# Altium schematic sheet ARK_Flow_Microcontroller.SchDoc(ARK_Flow_Microcontroller)
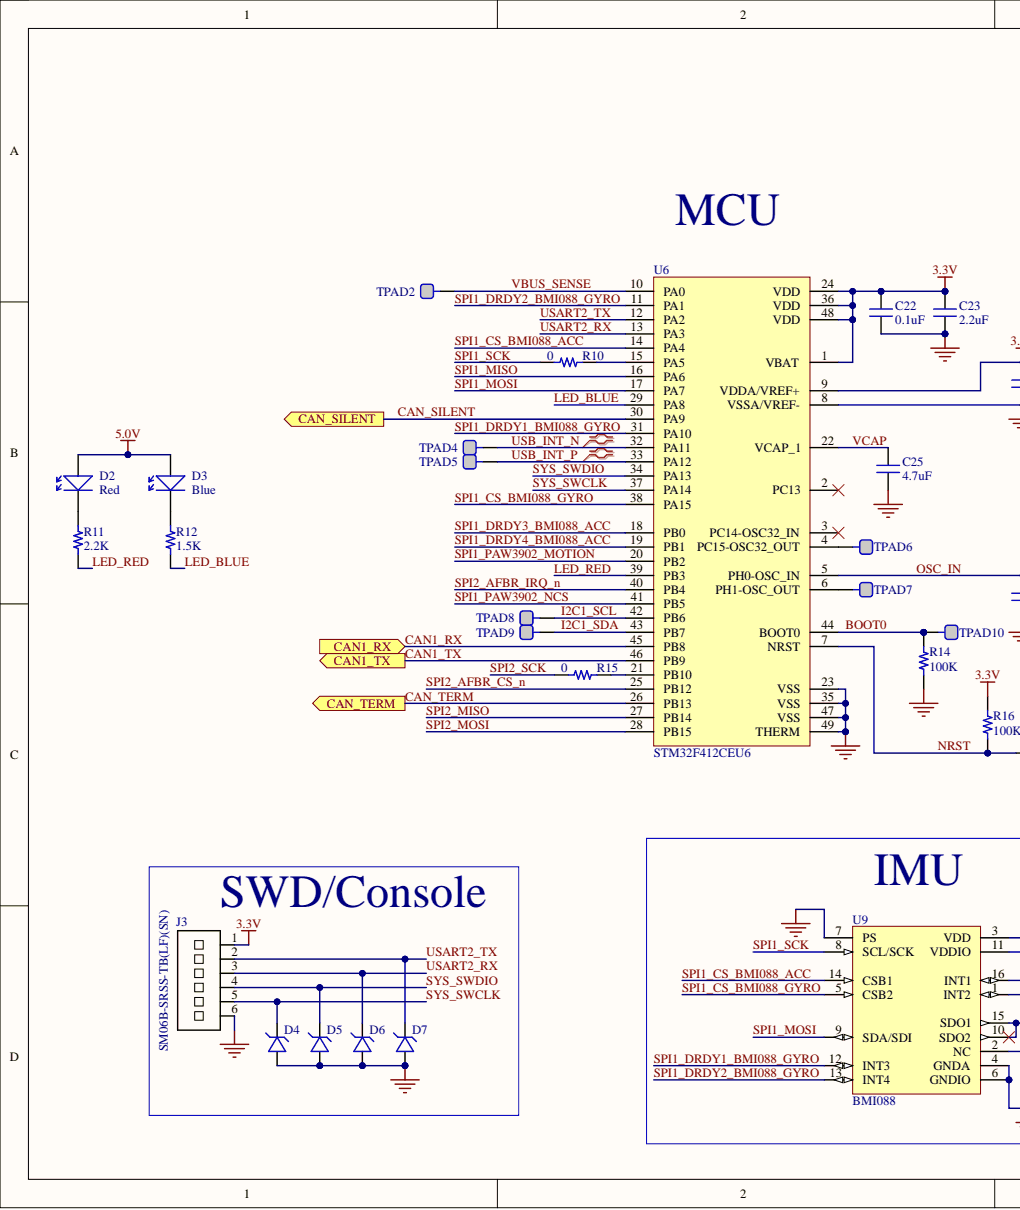
[diagram p0: ARK_Flow_Microcontroller.SchDoc, left]
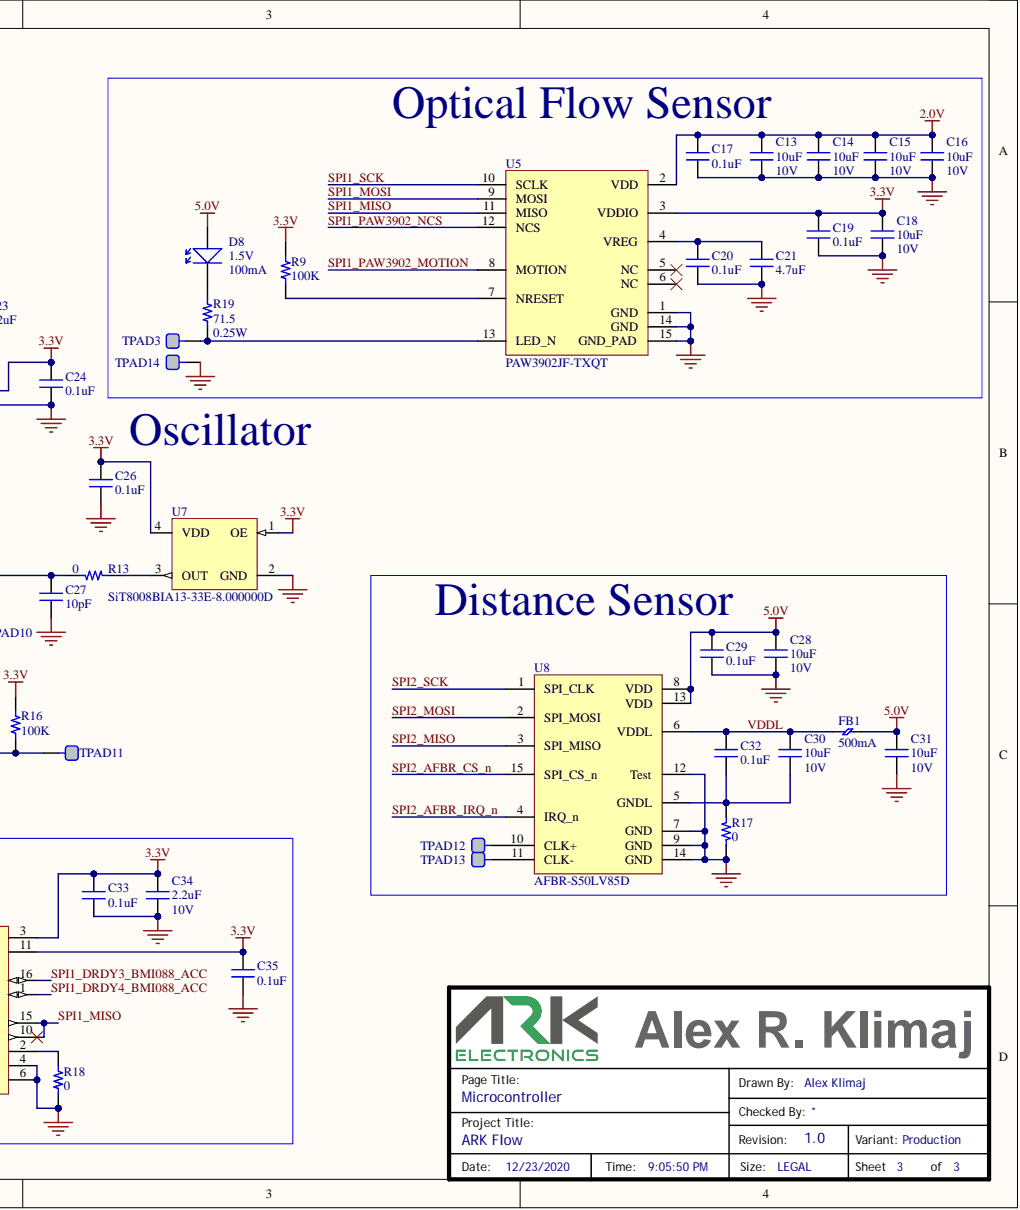
[diagram p1: ARK_Flow_Microcontroller.SchDoc, right]

## Parts
C13  10uF  Voltage_Rating: 10V  [CAP 0402/1005]
C14  10uF  Voltage_Rating: 10V  [CAP 0402/1005]
C15  10uF  Voltage_Rating: 10V  [CAP 0402/1005]
C16  10uF  Voltage_Rating: 10V  [CAP 0402/1005]
C17  value 0.1uF  [CAP 0402/1005]
C18  10uF  Voltage_Rating: 10V  [CAP 0402/1005]
C19  value 0.1uF  [CAP 0402/1005]
C20  value 0.1uF  [CAP 0402/1005]
C21  4.7uF  [CAP 0402/1005]
C22  value 0.1uF  [CAP 0402/1005]
C23  value 2.2uF  [CAP 0402/1005]  (hidden comment: Capacitor)
C24  value 0.1uF  [CAP 0402/1005]
C25  4.7uF  [CAP 0402/1005]
C26  value 0.1uF  [CAP 0402/1005]
C27  value 10pF  [CAP 0402/1005]
C28  10uF  Voltage_Rating: 10V  [CAP 0402/1005]
C29  value 0.1uF  [CAP 0402/1005]
C30  10uF  Voltage_Rating: 10V  [CAP 0402/1005]
C31  10uF  Voltage_Rating: 10V  [CAP 0402/1005]
C32  value 0.1uF  [CAP 0402/1005]
C33  0.1uF  [CAP 0402/1005]
C34  value 2.2uF  Voltage_Rating: 10V  [CAP 0402/1005]
C35  0.1uF  [CAP 0402/1005]
D2  LTST-C190KRKT  [LTST-C191TBKT]  (value not drawn)
D3  LTST-C191TBKT  [LTST-C191TBKT]  (value not drawn)
D4  (no value)  [SOD-923]
D5  (no value)  [SOD-923]
D6  (no value)  [SOD-923]
D7  (no value)  [SOD-923]
D8  Current_Rating: 100mA  Voltage_Rating: 1.5V  [IN-P32ZTIR]
FB1  Current_Rating: 500mA  [FER 0603/1608]
J3  value SM06B-SRSS-TB(LF)(SN)  [SM06B-SRSS-TB(LF)(SN)]
R9  100K  [RESC0402(1005)_N]
R10  0  [RESC0402(1005)_N]
R11  value 2.2K  [RESC0402(1005)_N]  (hidden comment: Resistor)
R12  1.5K  [RESC0402(1005)_N]
R13  0  [RESC0402(1005)_N]
R14  100K  [RESC0402(1005)_N]
R15  0  [RESC0402(1005)_N]
R16  100K  [RESC0402(1005)_N]
R17  0  [RESC0402(1005)_N]
R18  0  [RESC0402(1005)_N]
R19  71.5  Power_Rating: 0.25W  [RESC3216X04N]
TPAD2  (no value)  [TEST_PAD]  (no BOM)
TPAD3  (no value)  [TEST_POINT]  (no BOM)
TPAD4  (no value)  [TEST_PAD]  (no BOM)
TPAD5  (no value)  [TEST_PAD]  (no BOM)
TPAD6  (no value)  [TEST_PAD]  (no BOM)
TPAD7  (no value)  [TEST_PAD]  (no BOM)
TPAD8  (no value)  [TEST_PAD]  (no BOM)
TPAD9  (no value)  [TEST_PAD]  (no BOM)
TPAD10  (no value)  [TEST_POINT]  (no BOM)
TPAD11  (no value)  [TEST_POINT]  (no BOM)
TPAD12  (no value)  [TEST_PAD]  (no BOM)
TPAD13  (no value)  [TEST_PAD]  (no BOM)
TPAD14  (no value)  [TEST_POINT]  (no BOM)
U5  value PAW3902JF-TXQT  Mfr Part Number: PAW3902JF-TXQT  [PQFN65P500X500X236_HS-15N]
U6  STM32F412CEU6  [UFQFPN48]
U7  value SiT8008BIA13-33E-8.000000D  Voltage_Rating: 3.3V  [SiT8008BIA13-33E-8.000000D]
U8  value AFBR-S50LV85D  [AFBR-S50LV85D]
U9  BMI088  [BMI055]

## Ports
CAN1_RX, CAN1_TX, CAN_SILENT, CAN_TERM

## Nets
2.0V: C13.2 C14.2 C15.2 C16.2 C17.2 U5.2(VDD)
3.3V: C18.2 C19.2 C22.2 C23.2 C24.2 C26.2 C33.2 C34.2 C35.2 J3.1 R9.2 R16.2 U5.3(VDDIO) U6.1(VBAT) U6.9(VDDA/VREF+) U6.24(VDD) U6.36(VDD) U6.48(VDD) U7.1(OE) U7.4(VDD) U9.3(VDD) U9.11(VDDIO)
5.0V: C28.2 C29.2 C31.2 D2.2 D3.2 D8.A FB1.2 U8.8(VDD) U8.13(VDD)
GND: C13.1 C14.1 C15.1 C16.1 C17.1 C18.1 C19.1 C20.1 C21.1 C22.1 C23.1 C24.1 C25.1 C26.1 C27.1 C28.1 C29.1 C31.1 C33.1 C34.1 C35.1 D4.2 D5.2 D6.2 D7.2 J3.6 R14.1 R17.1 R18.1 TPAD14.1 U5.1(GND) U5.14(GND) U5.15(GND_PAD) U6.8(VSSA/VREF-) U6.23(VSS) U6.35(VSS) U6.47(VSS) U6.49(THERM) U7.2(GND) U8.7(GND) U8.9(GND) U8.12(Test) U8.14(GND) U9.4(GNDA) U9.6(GNDIO) U9.7(PS)
BOOT0: R14.2 TPAD10.1 U6.44(BOOT0)
CAN1_RX: U6.45(PB8) ; port CAN1_RX
CAN1_TX: U6.46(PB9) ; port CAN1_TX
CAN_SILENT: U6.30(PA9) ; port CAN_SILENT
CAN_TERM: U6.26(PB13) ; port CAN_TERM
I2C1_SCL: TPAD8.1 U6.42(PB6)
I2C1_SDA: TPAD9.1 U6.43(PB7)
LED_BLUE: R12.1 U6.29(PA8)
LED_RED: R11.1 U6.39(PB3)
NRST: R16.1 TPAD11.1 U6.7(NRST)
OSC_IN: C27.2 R13.1 U6.5(PH0-OSC_IN)
SPI1_CS_BMI088_ACC: U6.14(PA4) U9.14(CSB1)
SPI1_CS_BMI088_GYRO: U6.38(PA15) U9.5(CSB2)
SPI1_DRDY1_BMI088_GYRO: U6.31(PA10) U9.12(INT3)
SPI1_DRDY2_BMI088_GYRO: U6.11(PA1) U9.13(INT4)
SPI1_DRDY3_BMI088_ACC: U6.18(PB0) U9.16(INT1)
SPI1_DRDY4_BMI088_ACC: U6.19(PB1) U9.1(INT2)
SPI1_MISO: U5.11(MISO) U6.16(PA6) U9.10(SDO2) U9.15(SDO1)
SPI1_MOSI: U5.9(MOSI) U6.17(PA7) U9.9(SDA/SDI)
SPI1_PAW3902_MOTION: U5.8(MOTION) U6.20(PB2)
SPI1_PAW3902_NCS: U5.12(NCS) U6.41(PB5)
SPI1_SCK: R10.2 U5.10(SCLK) U9.8(SCL/SCK)
SPI2_AFBR_CS_n: U6.25(PB12) U8.15(SPI_CS_n)
SPI2_AFBR_IRQ_n: U6.40(PB4) U8.4(IRQ_n)
SPI2_MISO: U6.27(PB14) U8.3(SPI_MISO)
SPI2_MOSI: U6.28(PB15) U8.2(SPI_MOSI)
SPI2_SCK: R15.2 U8.1(SPI_CLK)
SYS_SWCLK: D4.1 J3.5 U6.37(PA14)
SYS_SWDIO: D5.1 J3.4 U6.34(PA13)
USART2_RX: D6.1 J3.3 U6.13(PA3)
USART2_TX: D7.1 J3.2 U6.12(PA2)
USB_INT_N: TPAD4.1 U6.32(PA11)
USB_INT_P: TPAD5.1 U6.33(PA12)
VBUS_SENSE: TPAD2.1 U6.10(PA0)
VCAP: C25.2 U6.22(VCAP_1)
VDDL: C30.2 C32.2 FB1.1 U8.6(VDDL)
NetC20_2: C20.2 C21.2 U5.4(VREG)
NetC30_1: C30.1 C32.1 R17.2 U8.5(GNDL)
NetD2_1: D2.1 R11.2
NetD3_1: D3.1 R12.2
NetD8_C: D8.C R19.2
NetR9_1: R9.1 U5.7(NRESET)
NetR10_1: R10.1 U6.15(PA5)
NetR13_2: R13.2 U7.3(OUT)
NetR15_1: R15.1 U6.21(PB10)
NetR18_2: R18.2 U9.2(NC)
NetR19_1: R19.1 TPAD3.1 U5.13(LED_N)
NetTPAD6_1: TPAD6.1 U6.4(PC15-OSC32_OUT)
NetTPAD7_1: TPAD7.1 U6.6(PH1-OSC_OUT)
NetTPAD12_1: TPAD12.1 U8.10(CLK+)
NetTPAD13_1: TPAD13.1 U8.11(CLK-)

Not connected: U5 5(NC) 6(NC); U6 2(PC13) 3(PC14-OSC32_IN)

## Library data of the parts
C13-C16 C18 C28 C30 C31 = 30418: SMT Capacitor; Manufacturer: Samsung Electro-Mechanics; Mfr Part Description: CAP CER 10uF ±20% 10V X5R 0402 (1005 Metric); Mfr Part Number: CL05A106MP8NUB8; Database Table Name: Parts; Dielectric: X5R; Tolerance: ±20%; Updated By: aklimaj; Component Kind: Standard; ComponentLink1Description: Datasheet; ComponentLink1URL: http://www.samsungsem.com/kr/support/product-search/mlcc/CL05A106MP8NUB8.jsp; ComponentLink2Description: Supplier Part Details; ComponentLink2URL: https://www.digikey.com/product-detail/en/samsung-electro-mechanics/CL05A106MP8NUB8/1276-6830-1-ND/5961689; Created_Date: 2019/04/03 13:12:18; ID: 30418; Library Name: Parts.DbLib; LifeCycle: New; Package_Case: 0402 (1005 Metric); Part_Type: Capacitor; RoHS: Yes; Supplier: Digi-Key; Supplier Part Number: 1276-6830-1-ND; Temperature Range: -55C to +85C; Updated_Date: 2019/04/03 13:12:36; Value: 10uF; Created By: aklimaj
C17 C19 C20 C22 C24 C26 C29 C32 = 30007: SMT Capacitor; Manufacturer: Murata; Mfr Part Description: CAP CER 0.1uF ±10% 25V X5R 0402 (1005 Metric); Mfr Part Number: GRM155R61E104KA87D; Database Table Name: Parts; Dielectric: X5R; Tolerance: ±10%; Updated By: aklimaj; Voltage_Rating: 25V; Component Kind: Standard; ComponentLink1Description: Datasheet; ComponentLink1URL: http://search.murata.co.jp/Ceramy/image/img/A01X/partnumbering_e_01.pdf; ComponentLink2Description: Supplier Part Details; ComponentLink2URL: https://www.digikey.com/product-detail/en/murata-electronics-north-america/GRM155R61E104KA87D/490-5920-1-ND/3721277; Created_Date: 2017/07/11 17:31:38; ID: 30007; Library Name: Parts.DbLib; LifeCycle: New; Package_Case: 0402 (1005 Metric); Part_Type: Capacitor; RoHS: Yes; Supplier: Digi-Key; Supplier Part Number: 490-5920-1-ND; Temperature Range: -55C to +85C; Updated_Date: 2017/07/11 17:32:00; Created By: aklimaj
C21 C25 = 30302: SMT Capacitor; Manufacturer: TDK Corporation; Mfr Part Description: CAP CER 4.7uF ±10% 6.3V X5R 0402 (1005 Metric); Mfr Part Number: C1005X5R0J475K050BC; Database Table Name: Parts; Dielectric: X5R; Tolerance: ±10%; Updated By: aklimaj; Voltage_Rating: 6.3V; Component Kind: Standard; ComponentLink1Description: Datasheet; ComponentLink1URL: https://product.tdk.com/info/en/catalog/datasheets/mlcc_commercial_general_en.pdf; ComponentLink2Description: Supplier Part Details; ComponentLink2URL: https://www.digikey.com/products/en?keywords=445-5947-1-ND&stock=1; Created_Date: 2019/02/28 17:07:10; ID: 30302; Library Name: Parts.DbLib; LifeCycle: New; Package_Case: 0402 (1005 Metric); Part_Type: Capacitor; RoHS: Yes; Supplier: Digi-Key; Supplier Part Number: 445-5947-1-ND; Temperature Range: -55C to +85C; Updated_Date: 2019/02/28 17:07:25; Value: 4.7uF; Created By: aklimaj
C23 = 30151: SMT Capacitor; Manufacturer: Murata; Mfr Part Description: CAP CER 2.2uF ±20% 10V X5R 0402 (1005 Metric); Mfr Part Number: GRM155R61A225ME95D; Database Table Name: Parts; Dielectric: X5R; Tolerance: ±20%; Updated By: aklimaj; Voltage_Rating: 10V; Component Kind: Standard; ComponentLink1Description: Datasheet; ComponentLink1URL: http://search.murata.co.jp/Ceramy/image/img/A01X/partnumbering_e_01.pdf; ComponentLink2Description: Supplier Part Details; ComponentLink2URL: https://www.digikey.com/product-detail/en/murata-electronics-north-america/GRM155R61A225ME95D/490-10452-2-ND/5027537; Created_Date: 2017/11/02 14:41:38; ID: 30151; Library Name: Parts.DbLib; LifeCycle: New; Package_Case: 0402 (1005 Metric); Part_Type: Capacitor; RoHS: Yes; Supplier: Digi-Key; Supplier Part Number: 490-10452-2-ND; Temperature Range: -55C to +85C; Updated_Date: 2018/10/19 21:38:16; Created By: aklimaj
C27 = 30152: SMT Capacitor; Manufacturer: Murata; Mfr Part Description: CAP CER 10pF ±5% 50V NPO 0402 (1005 Metric); Mfr Part Number: GRM1555C1H100JA01D; Database Table Name: Parts; Dielectric: NPO; Tolerance: ±5%; Updated By: aklimaj; Voltage_Rating: 50V; Component Kind: Standard; ComponentLink1Description: Datasheet; ComponentLink1URL: http://search.murata.co.jp/Ceramy/image/img/A01X/partnumbering_e_01.pdf; ComponentLink2Description: Supplier Part Details; ComponentLink2URL: https://www.digikey.com/products/en?keywords=490-5921-2-ND&stock=1; Created_Date: 2017/11/02 14:42:40; ID: 30152; Library Name: Parts.DbLib; LifeCycle: New; Package_Case: 0402 (1005 Metric); Part_Type: Capacitor; RoHS: Yes; Supplier: Digi-Key; Supplier Part Number: 490-5921-2-ND; Temperature Range: -55C to +125C; Updated_Date: 2018/10/19 21:38:15; Created By: aklimaj
C33 C35 = 30007: SMT Capacitor; Manufacturer: Walsin Technology Corporation; Mfr Part Description: CAP CER 0.1uF ±10% 25V X5R 0402 (1005 Metric); Mfr Part Number: 0402X104K250CT; Database Table Name: Parts; Dielectric: X5R; Tolerance: ±10%; Updated By: aklimaj; Voltage_Rating: 25V; Component Kind: Standard; ComponentLink1Description: Datasheet; ComponentLink1URL: http://www.passivecomponent.com/wp-content/uploads/2018/10/MLCC.pdf; ComponentLink2Description: Supplier Part Details; ComponentLink2URL: https://www.digikey.com/products/en?keywords=0402X104K250CT; Created_Date: 2017/07/11 17:31:38; ID: 30007; Library Name: Parts.DbLib; LifeCycle: New; Package_Case: 0402 (1005 Metric); Part_Type: Capacitor; RoHS: Yes; Supplier: Digi-Key; Supplier Part Number: 1292-1640-2-ND; Temperature Range: -55C to +85C; Updated_Date: 2020/12/17 20:30:00; Value: 0.1uF; Created By: aklimaj
C34 = 30151: SMT Capacitor; Manufacturer: Murata; Mfr Part Description: CAP CER 2.2uF ±20% 10V X5R 0402 (1005 Metric); Mfr Part Number: GRM155R61A225ME95D; Database Table Name: Parts; Dielectric: X5R; Tolerance: ±20%; Updated By: aklimaj; Component Kind: Standard; ComponentLink1Description: Datasheet; ComponentLink1URL: http://search.murata.co.jp/Ceramy/image/img/A01X/partnumbering_e_01.pdf; ComponentLink2Description: Supplier Part Details; ComponentLink2URL: https://www.digikey.com/product-detail/en/murata-electronics-north-america/GRM155R61A225ME95D/490-10452-2-ND/5027537; Created_Date: 2017/11/02 14:41:38; ID: 30151; Library Name: Parts.DbLib; LifeCycle: New; Package_Case: 0402 (1005 Metric); Part_Type: Capacitor; RoHS: Yes; Supplier: Digi-Key; Supplier Part Number: 490-10452-2-ND; Temperature Range: -55C to +85C; Updated_Date: 2018/10/19 21:38:16; Created By: aklimaj
D2 = 30943: Manufacturer: Lite-On Inc.; Mfr Part Description: LED RED CLEAR CHIP SMD; Part_Type: Discrete; Current_Rating: 20mA; Database Table Name: Parts; Updated By: aklimaj; Voltage_Rating: 2V; Component Kind: Standard; ComponentLink1Description: Datasheet; ComponentLink1URL: http://optoelectronics.liteon.com/upload/download/DS-22-99-0151/LTST-C190KRKT.pdf; ComponentLink2Description: Supplier Part Details; ComponentLink2URL: https://www.digikey.com/products/en?keywords=LTST-C190KRKT; Created_Date: 2020/12/11 17:10:57; ID: 30943; Library Name: Parts.DbLib; LifeCycle: New; Mfr Part Number: LTST-C190KRKT; Package_Case: 0603 (1608 Metric); RoHS: Yes; Supplier: Digi-Key; Supplier Part Number: 160-1436-2-ND; Updated_Date: 2020/12/11 17:11:02; Value: LTST-C190KRKT; Created By: aklimaj
D3 = 30059: LTST-C191TBKT LED BLUE CLEAR 0603 SMD; Manufacturer: Lite-On Inc.; Part_Type: Discrete; Current_Rating: 20mA; Database Table Name: Parts; Updated By: aklimaj; Voltage_Rating: 3.3V; Component Kind: Standard; ComponentLink1Description: Datasheet; ComponentLink1URL: http://optoelectronics.liteon.com/upload/download/DS22-2000-230/LTST-C191TBKT.pdf; ComponentLink2Description: Supplier Part Details; ComponentLink2URL: https://www.digikey.com/product-detail/en/lite-on-inc/LTST-C191TBKT/160-1647-1-ND/573587; Created_Date: 2017/09/05 17:40:57; ID: 30059; Library Name: Parts.DbLib; LifeCycle: New; Mfr Part Description: LED BLUE CLEAR 0603 SMD; Mfr Part Number: LTST-C191TBKT; Package_Case: 0603 (1608 Metric); RoHS: Yes; Supplier: Digi-Key; Supplier Part Number: 160-1647-1-ND; Updated_Date: 2018/10/19 21:38:38; Value: LTST-C191TBKT; Created By: aklimaj
D4-D7 = 30166: TVS Diode; Manufacturer: ON Semiconductor; Mfr Part Description: TVS DIODE 3.3VWM SOD923; Mfr Part Number: ESD9C3.3ST5G; Part_Type: Protection; Database Table Name: Parts; Updated By: aklimaj; Value: ESD9C3.3ST5G; Voltage_Rating: 3.3V (Max); Component Kind: Standard; ComponentLink1Description: Datasheet; ComponentLink1URL: http://www.onsemi.com/pub/Collateral/ESD9C3.3S-D.PDF; ComponentLink2Description: Supplier Part Details; ComponentLink2URL: https://www.digikey.com/product-detail/en/on-semiconductor/ESD9C3.3ST5G/ESD9C3.3ST5GOSCT-ND/1646498; Created_Date: 2017/12/13 10:54:56; ID: 30166; Library Name: Parts.DbLib; LifeCycle: New; Package_Case: SOD-923; RoHS: Yes; Supplier: Digi-Key; Supplier Part Number: ESD9C3.3ST5GOSCT-ND; Temperature Range: -55C to +150C (TJ); Updated_Date: 2018/10/19 21:38:12; Created By: aklimaj
D8 = 30958: Manufacturer: Inolux; Mfr Part Description: TOP VIEW / PLCC2 / 3.5X2.8X1.9; Part_Type: Discrete; Database Table Name: Parts; Updated By: aklimaj; Value: IN-P32ZTIR; Component Kind: Standard; ComponentLink1Description: Datasheet; ComponentLink1URL: http://www.inolux-corp.com/datasheet/IR/Emitter/850%20nm%20SMD/IN-P32ZTIR_V1.0.pdf; ComponentLink2Description: Supplier Part Details; ComponentLink2URL: https://www.digikey.com/products/en?keywords=IN-P32ZTIR; Created_Date: 2020/12/23 15:09:47; ID: 30958; Library Name: Parts.DbLib; LifeCycle: New; Mfr Part Number: IN-P32ZTIR; Package_Case: 1411 (3528 Metric); RoHS: Yes; Supplier: Digi-Key; Supplier Part Number: 1830-IN-P32ZTIRTR-ND; Temperature Range: -40C to +85C; Updated_Date: 2020/12/23 15:30:04; Created By: aklimaj
FB1 = 30953: SMT Ferrite Chip; Manufacturer: Murata Electronics; Mfr Part Description: FERRITE BEAD 1.5 KOHM 0603 1LN; Mfr Part Number: BLM18HE152SN1D; Part_Type: Filter; Database Table Name: Parts; Updated By: aklimaj; Value: BLM18HE152SN1D; Component Kind: Standard; ComponentLink1Description: Datasheet; ComponentLink1URL: https://www.murata.com/en-us/products/productdata/8796741599262/ENFA0004.pdf; ComponentLink2Description: Supplier Part Details; ComponentLink2URL: https://www.digikey.com/products/en?keywords=BLM18HE152SN1D; Created_Date: 2020/12/22 19:10:16; ID: 30953; Library Name: Parts.DbLib; LifeCycle: New; Package_Case: 0603 (1608 Metric); RoHS: Yes; Supplier: Digi-Key; Supplier Part Number: 490-5216-2-ND; Temperature Range: -55C to +125C; Updated_Date: 2020/12/22 19:11:02; Created By: aklimaj
J3 = 30085: CONN HEADER SH 6POS SIDE 1MM TIN; Manufacturer: JST Sales America Inc.; Part_Type: Connector; Current_Rating: 0.7A; Database Table Name: Parts; Updated By: aklimaj; Voltage_Rating: 50V; Component Kind: Standard; ComponentLink1Description: Datasheet; ComponentLink1URL: http://www.jst-mfg.com/product/pdf/eng/eSH.pdf; ComponentLink2Description: Supplier Part Details; ComponentLink2URL: https://www.digikey.com/product-detail/en/jst-sales-america-inc/SM06B-SRSS-TB(LF)(SN)/455-1806-1-ND/926877; Created_Date: 2017/09/13 10:26:52; ID: 30085; Library Name: Parts.DbLib; LifeCycle: New; Mfr Part Description: CONN HEADER SH 6POS SIDE 1MM TIN; Mfr Part Number: SM06B-SRSS-TB(LF)(SN); RoHS: Yes; Supplier: Digi-Key; Supplier Part Number: 455-1806-1-ND; Temperature Range: -25C to +85C; Updated_Date: 2018/10/19 21:38:32; Created By: aklimaj
R9 R14 R16 = 30333: SMT Resistor; Manufacturer: Yageo; Mfr Part Description: RES 100K Ohm ±5% 1/16W 0402 (1005 Metric); Mfr Part Number: AC0402JR-07100KL; Database Table Name: Parts; Power_Rating: 0.063W, 1/16W; Temperature Coeff: ±100ppm/degC; Tolerance: ±5%; Updated By: aklimaj; Component Kind: Standard; ComponentLink1Description: Datasheet; ComponentLink1URL: http://www.yageo.com/NewPortal/yageodocoutput?fileName=/pdf/R-Chip/PYu-AC_51_RoHS_L_6.pdf; ComponentLink2Description: Supplier Part Details; ComponentLink2URL: https://www.digikey.com/products/en?keywords=YAG3515CT-ND&stock=1; Created_Date: 2019/02/28 18:55:55; ID: 30333; Library Name: Parts.DbLib; LifeCycle: New; Package_Case: 0402 (1005 Metric); Part_Type: Resistor; RoHS: Yes; Supplier: Digi-Key; Supplier Part Number: YAG3515CT-ND; Temperature Range: -55C to +155C; Updated_Date: 2019/02/28 18:56:10; Value: 100K; Created By: aklimaj
R10 R13 R15 R17 R18 = 30327: SMT Resistor; Manufacturer: Yageo; Mfr Part Description: RES 0 Ohm Jumper 1/16W 0402 (1005 Metric); Mfr Part Number: AC0402JR-070RL; Database Table Name: Parts; Power_Rating: 0.063W, 1/16W; Tolerance: Jumper; Updated By: aklimaj; Component Kind: Standard; ComponentLink1Description: Datasheet; ComponentLink2Description: Supplier Part Details; Created_Date: 2019/02/28 18:42:38; ID: 30327; Library Name: Parts.DbLib; LifeCycle: New; Package_Case: 0402 (1005 Metric); Part_Type: Resistor; RoHS: Yes; Supplier: Digi-Key; Supplier Part Number: 311-0.0LBCT-ND; Temperature Range: -55C to +155C; Value: 0; Created By: aklimaj
  R10 R13 R15 R17: ComponentLink1URL: http://www.yageo.com/NewPortal/yageodocoutput?fileName=/pdf/R-Chip/PYu-AC_51_RoHS_L_6.pdf; ComponentLink2URL: https://www.digikey.com/products/en?keywords=311-0.0LBCT-ND&stock=1; Updated_Date: 2019/02/28 18:42:52
  R18: ComponentLink1URL: https://www.yageo.com/upload/media/product/productsearch/datasheet/rchip/PYu-AC_51_RoHS_L_7.pdf; ComponentLink2URL: https://www.digikey.com/product-detail/en/AC0402JR-070RL/311-0.0LBCT-ND; Updated_Date: 2020/05/04 13:23:15
R11 = 30054: SMT Resistor; Manufacturer: Yageo; Mfr Part Description: RES 2.2K Ohm ±1% 1/16W 0402 (1005 Metric); Mfr Part Number: RC0402FR-072K2L; Database Table Name: Parts; Power_Rating: 0.063W, 1/16W; Temperature Coeff: ±100ppm/degC; Tolerance: ±1%; Updated By: aklimaj; Component Kind: Standard; ComponentLink1Description: Datasheet; ComponentLink1URL: http://www.yageo.com.tw/exep/pages/download/literatures/PYu-R_INT-thick_7.pdf; ComponentLink2Description: Supplier Part Details; ComponentLink2URL: https://www.digikey.com/product-detail/en/yageo/RC0402FR-072K2L/311-2.20KLRCT-ND/729500; Created_Date: 2017/09/05 16:50:20; ID: 30054; Library Name: Parts.DbLib; LifeCycle: New; Package_Case: 0402 (1005 Metric); Part_Type: Resistor; RoHS: Yes; Supplier: Digi-Key; Supplier Part Number: 311-2.20KLRCT-ND; Temperature Range: -55C to +155C; Updated_Date: 2018/10/19 21:38:39; Created By: aklimaj
R12 = 30457: SMT Resistor; Manufacturer: Stackpole Electronics Inc; Mfr Part Description: RES 1.5K Ohm ±1% 1/16W 0402 (1005 Metric); Mfr Part Number: RMCF0402FT1K50; Database Table Name: Parts; Power_Rating: 0.063W, 1/16W; Temperature Coeff: ±100ppm/degC; Tolerance: ±1%; Updated By: aklimaj; Component Kind: Standard; ComponentLink1Description: Datasheet; ComponentLink1URL: https://www.seielect.com/catalog/sei-rmcf_rmcp.pdf; ComponentLink2Description: Supplier Part Details; ComponentLink2URL: https://www.digikey.com/product-detail/en/stackpole-electronics-inc/RMCF0402FT1K50/RMCF0402FT1K50CT-ND/1942921; Created_Date: 2019/05/29 12:51:28; ID: 30457; Library Name: Parts.DbLib; LifeCycle: New; Package_Case: 0402 (1005 Metric); Part_Type: Resistor; RoHS: Yes; Supplier: Digi-Key; Supplier Part Number: RMCF0402FT1K50CT-ND; Temperature Range: -55C to +155C; Updated_Date: 2019/05/29 12:51:50; Value: 1.5K; Created By: aklimaj
R19 = 30959: SMT Resistor; Manufacturer: Stackpole Electronics Inc; Mfr Part Description: RES 71.5 Ohm ±1% 0.25W, 1/4W 1206 (3216 Metric); Mfr Part Number: RMCF1206FG71R5; Database Table Name: Parts; Temperature Coeff: ±100ppm/degC; Tolerance: ±1%; Updated By: aklimaj; Component Kind: Standard; ComponentLink1Description: Datasheet; ComponentLink1URL: https://www.seielect.com/catalog/sei-rmcf_rmcp.pdf; ComponentLink2Description: Supplier Part Details; ComponentLink2URL: https://www.digikey.com/products/en?keywords=RMCF1206FG71R5; Created_Date: 2020/12/23 15:24:21; ID: 30959; Library Name: Parts.DbLib; LifeCycle: New; Package_Case: 1206 (3216 Metric); Part_Type: Resistor; RoHS: Yes; Supplier: Digi-Key; Supplier Part Number: RMCF1206FG71R5TR-ND; Temperature Range: -55C to +155C; Updated_Date: 2020/12/23 15:24:43; Value: 71.5; Created By: aklimaj
TPAD2-TPAD14 = 30015: TEST_PAD; Mfr Part Description: Test Point; Database Table Name: Parts; Updated By: aklimaj; ComponentLink1Description: Datasheet; ComponentLink2Description: Supplier Part Details; Created_Date: 2017/07/13 09:26:35; ID: 30015; Library Name: Parts.DbLib; LifeCycle: New; Part_Type: Test Point; RoHS: Yes; Updated_Date: 2019/07/19 12:21:04; Created By: aklimaj
U5 = 30954: Manufacturer: Pixart; Mfr Part Description: Sensor_Optical Sensor_Lighting Sensor; Database Table Name: Parts; Updated By: aklimaj; Component Kind: Standard; ComponentLink1Description: Datasheet; ComponentLink1URL: https://www.codico.com/media/productattach/p/i/pixart_paw3902jf-txqt_-_productbrief_1446592_6.pdf; ComponentLink2Description: Supplier Part Details; ComponentLink2URL: https://eshop.wpgam.com/paw3902jf-txqt#product-details-tab-description; Created_Date: 2020/12/22 19:56:29; ID: 30954; Library Name: Parts.DbLib; LifeCycle: New; RoHS: Yes; Supplier: WPG; Supplier Part Number: PAW3902JF-TXQT; Updated_Date: 2020/12/22 20:18:00; Created By: aklimaj
U6 = 30579: Manufacturer: STMicroelectronics; Mfr Part Description: ARM® Cortex®-M4 STM32F4 Microcontroller IC 32-Bit 100MHz 512KB (512K x 8) FLASH 48-UFQFPN (7x7); Part_Type: IC; Database Table Name: Parts; Updated By: aklimaj; Component Kind: Standard; ComponentLink1Description: Datasheet; ComponentLink1URL: http://www.st.com/content/ccc/resource/technical/document/datasheet/15/90/07/b3/8c/10/4e/95/DM00213872.pdf/files/DM00213872.pdf/jcr:content/translations/en.DM00213872.pdf; ComponentLink2Description: Supplier Part Details; ComponentLink2URL: https://www.digikey.com/product-detail/en/stmicroelectronics/STM32F412CEU6/497-18545-ND/6621739; Created_Date: 2019/10/28 15:48:57; ID: 30579; Library Name: Parts.DbLib; LifeCycle: New; Mfr Part Number: STM32F412CEU6; Package_Case: 48-UFQFN Exposed Pad; RoHS: Yes; Supplier: Digi-Key; Supplier Part Number: 497-18545-ND; Temperature Range: -40C to +85C (TA); Updated_Date: 2019/10/28 16:16:12; Value: STM32F412CEU6; Created By: aklimaj
U7 = 30182: Manufacturer: SiTIME; Mfr Part Description: OSC MEMS 8.0000MHZ LVCMOS SMD; Part_Type: OSC; Current_Rating: 4.5mA; Database Table Name: Parts; Notes: 8MHz MEMS (Silicon) LVCMOS Oscillator 3.3V Enable/Disable 4-SMD, No Lead; Updated By: aklimaj; Component Kind: Standard; ComponentLink1Description: Datasheet; ComponentLink1URL: https://www.sitime.com/datasheet/SiT8008; ComponentLink2Description: Supplier Part Details; ComponentLink2URL: https://www.digikey.com/product-detail/en/sitime/SIT8008BI-13-33E-8.000000D/SIT8008BI-13-33E-8.000000D-ND/6094964; Created_Date: 2018/04/03 10:22:48; ID: 30182; Library Name: Parts.DbLib; LifeCycle: New; Mfr Part Number: SiT8008BIA13-33E-8.000000D; Package_Case: 4-SMD, No Lead; RoHS: Yes; Supplier: Digi-Key; Supplier Part Number: SIT8008BI-13-33E-8.000000D-ND; Temperature Range: -40C to +85C; Updated_Date: 2018/10/19 21:38:08; Created By: aklimaj
U8 = 30952: Manufacturer: Broadcom Limited; Mfr Part Description: 850NM LONGRANGE 1D TOF SENSOR; Part_Type: 850NM; Current_Rating: 33mA; Database Table Name: Parts; Updated By: aklimaj; Voltage_Rating: 4.5V ~ 5.5V; Component Kind: Standard; ComponentLink1Description: Datasheet; ComponentLink1URL: https:/en/supplier-centers/broadcom; ComponentLink2Description: Supplier Part Details; ComponentLink2URL: https://www.digikey.com/products/en?keywords=AFBR-S50LV85D; Created_Date: 2020/12/22 18:09:48; ID: 30952; Library Name: Parts.DbLib; LifeCycle: New; Mfr Part Number: AFBR-S50LV85D; Supplier: Digi-Key; Supplier Part Number: 516-AFBR-S50LV85D-ND; Temperature Range: -20C to +70C; Updated_Date: 2020/12/22 19:00:34; Created By: aklimaj
U9 = 30935: Manufacturer: Bosch Sensortec; Mfr Part Description: ACCELEROMETER 16LGA; Part_Type: ACCELEROMETER; Database Table Name: Parts; Updated By: aklimaj; Component Kind: Standard; ComponentLink1Description: Datasheet; ComponentLink1URL: https://ae-bst.resource.bosch.com/media/_tech/media/datasheets/BST-BMI088-DS001.pdf; ComponentLink2Description: Supplier Part Details; ComponentLink2URL: https://www.digikey.com/products/en?keywords=BMI088; Created_Date: 2020/12/03 15:52:06; ID: 30935; Library Name: Parts.DbLib; LifeCycle: New; Mfr Part Number: BMI088; Package_Case: 16-VFLGA Module; RoHS: Yes; Supplier: Digi-Key; Supplier Part Number: 828-1082-2-ND; Temperature Range: -40C to +85C; Updated_Date: 2020/12/03 16:00:43; Value: BMI088; Created By: aklimaj
